# Altium schematic sheet [11] Écran & Dalle tactile.SchDoc("[11] Écran & Dalle tactile")
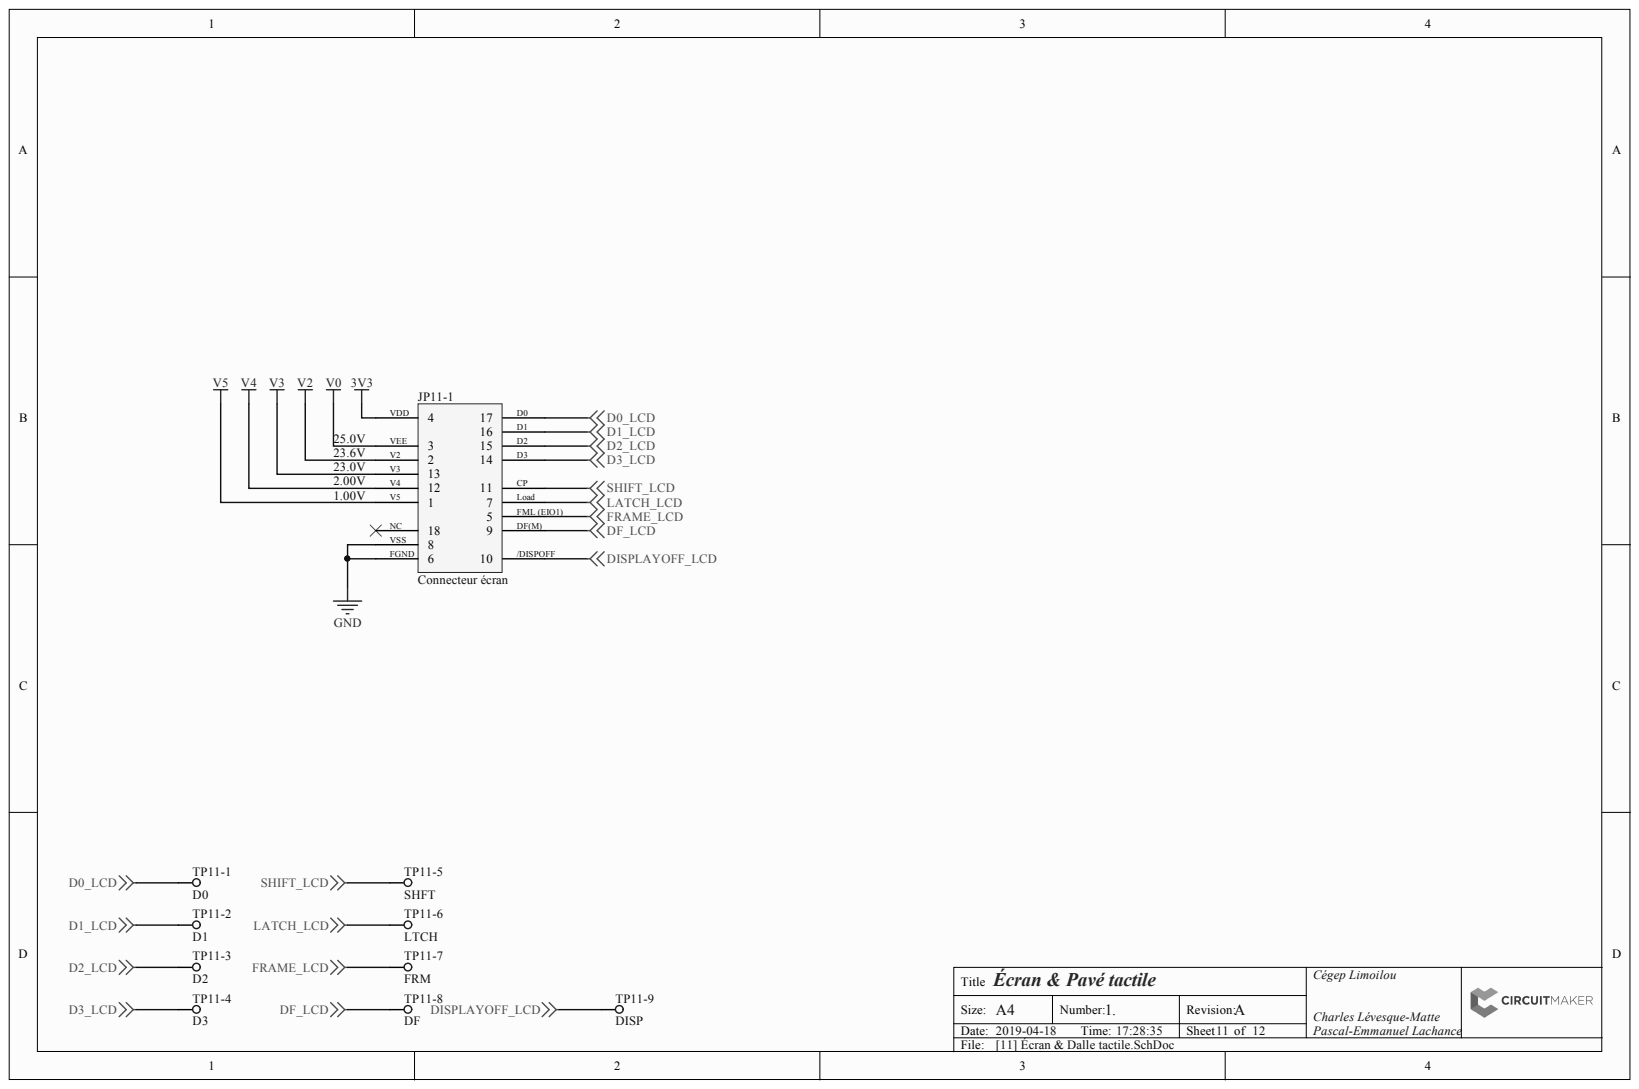
[diagram p0: [11] Écran & Dalle tactile.SchDoc]

## Parts
JP11-1  Connecteur écran  [PCB-ak0j7niyx9db86qdpfdn-1]
TP11-1  D0  [PCB-a7rpxwhzqdkz91v608la-1]
TP11-2  D1  [PCB-a7rpxwhzqdkz91v608la-1]
TP11-3  D2  [PCB-a7rpxwhzqdkz91v608la-1]
TP11-4  D3  [PCB-a7rpxwhzqdkz91v608la-1]
TP11-5  SHFT  [PCB-a7rpxwhzqdkz91v608la-1]
TP11-6  LTCH  [PCB-a7rpxwhzqdkz91v608la-1]
TP11-7  FRM  [PCB-a7rpxwhzqdkz91v608la-1]
TP11-8  DF  [PCB-a7rpxwhzqdkz91v608la-1]
TP11-9  DISP  [PCB-a7rpxwhzqdkz91v608la-1]

## Nets
3V3: JP11-1.4
D0_LCD: JP11-1.17 TP11-1.1
D1_LCD: JP11-1.16 TP11-2.1
D2_LCD: JP11-1.15 TP11-3.1
D3_LCD: JP11-1.14 TP11-4.1
DF_LCD: JP11-1.9 TP11-8.1
DISPLAYOFF_LCD: JP11-1.10 TP11-9.1
FRAME_LCD: JP11-1.5 TP11-7.1
GND: JP11-1.6 JP11-1.8
LATCH_LCD: JP11-1.7 TP11-6.1
SHIFT_LCD: JP11-1.11 TP11-5.1
V0: JP11-1.3
V2: JP11-1.2
V3: JP11-1.13
V4: JP11-1.12
V5: JP11-1.1

Not connected: JP11-1 18

## Library data of the parts
JP11-1 = CMP-605136-5: 1.00MM Ffc/fpc Connectors Ra SMT Zif Bottom 18 Ffc/fpc; Manufacturer Part Number: 52271-1879; Contact Resistance: 20.0 Ω; Gender: Female; Number of Circuits: 18; Component Kind: Standard; Contact Material: Phosphor Bronze; Flammability Rating: UL 94 V-0; Halogen Free Status: Not Low Halogen; Housing Material: Thermoplastic; Library Name: CommunityVault; Number of Rows: 1; OctopartID: 2190e891d81afe57; Octopart URL: https://octopart.com/52271-1879-molex-777452; Operating Temperature: -40.0 °C to 85.0 °C; Pin Pitch: 1.00 mm
TP11-1-TP11-9 = CMP-5j384tb079h9dvgbp04a-2: Through-hole Testpoint; Component Kind: Standard; Library Name: CommunityVault
